# Altium schematic sheet DE-160516.SchDoc(DE-160516)
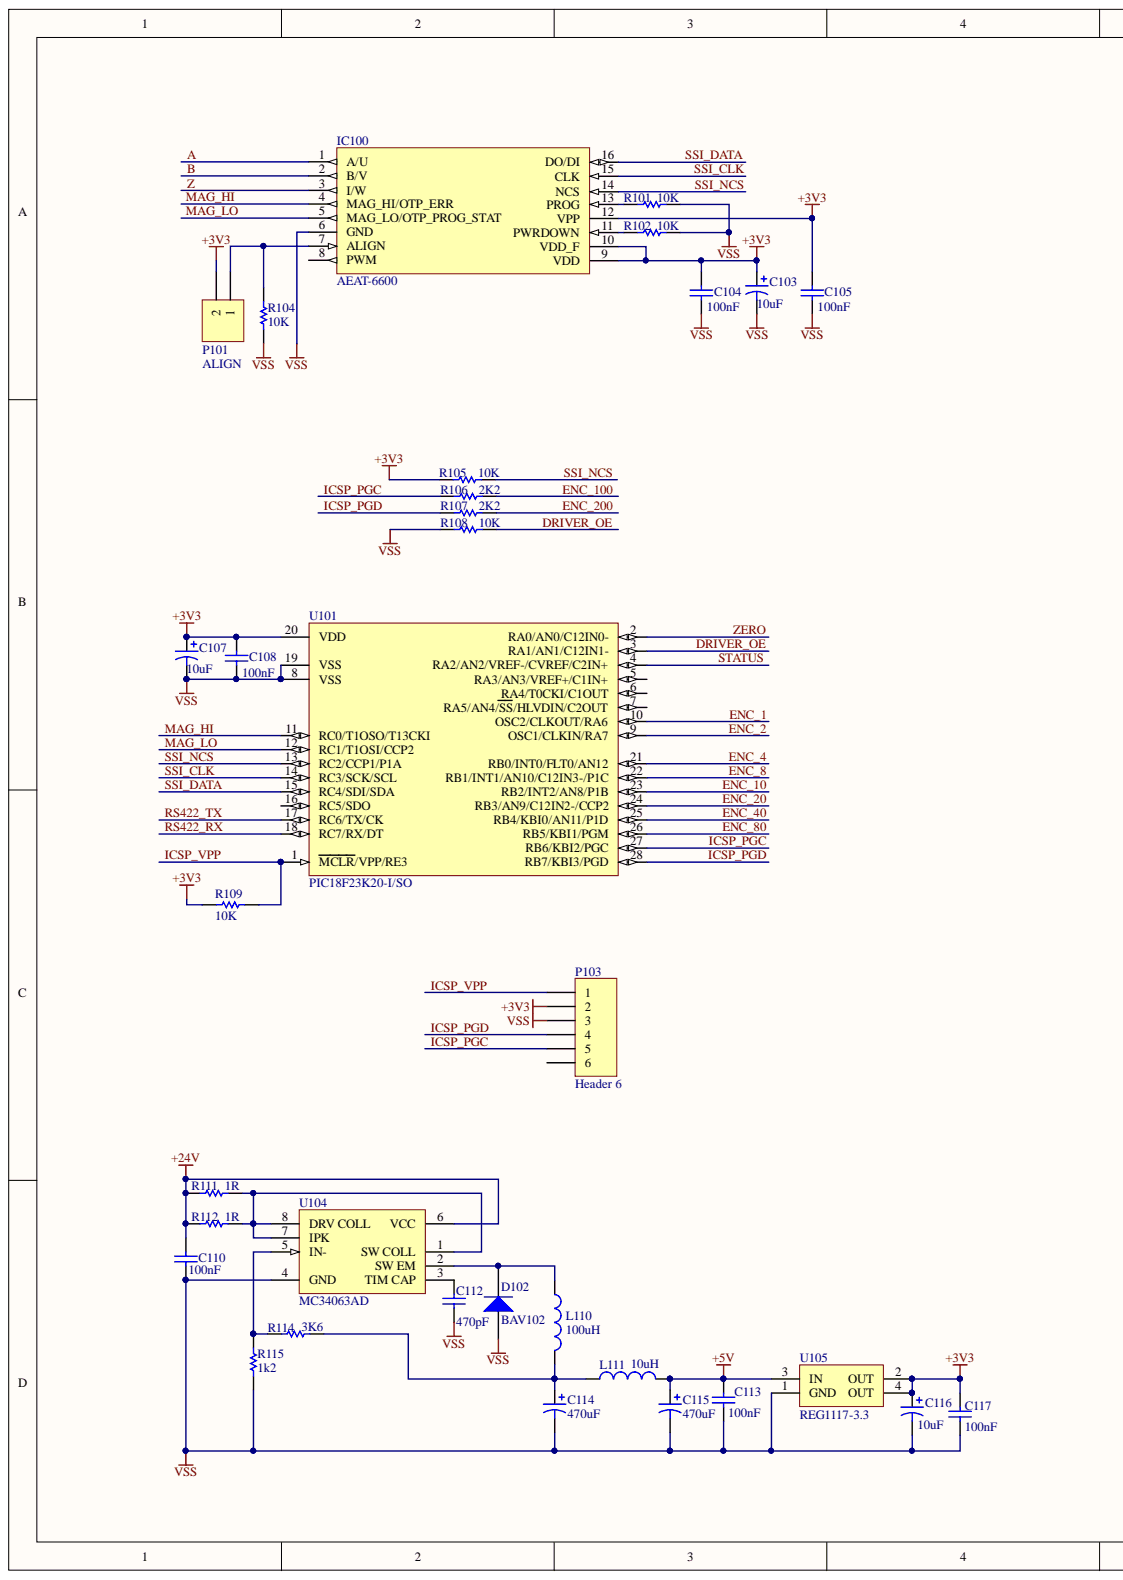
[diagram p0: DE-160516.SchDoc, left]
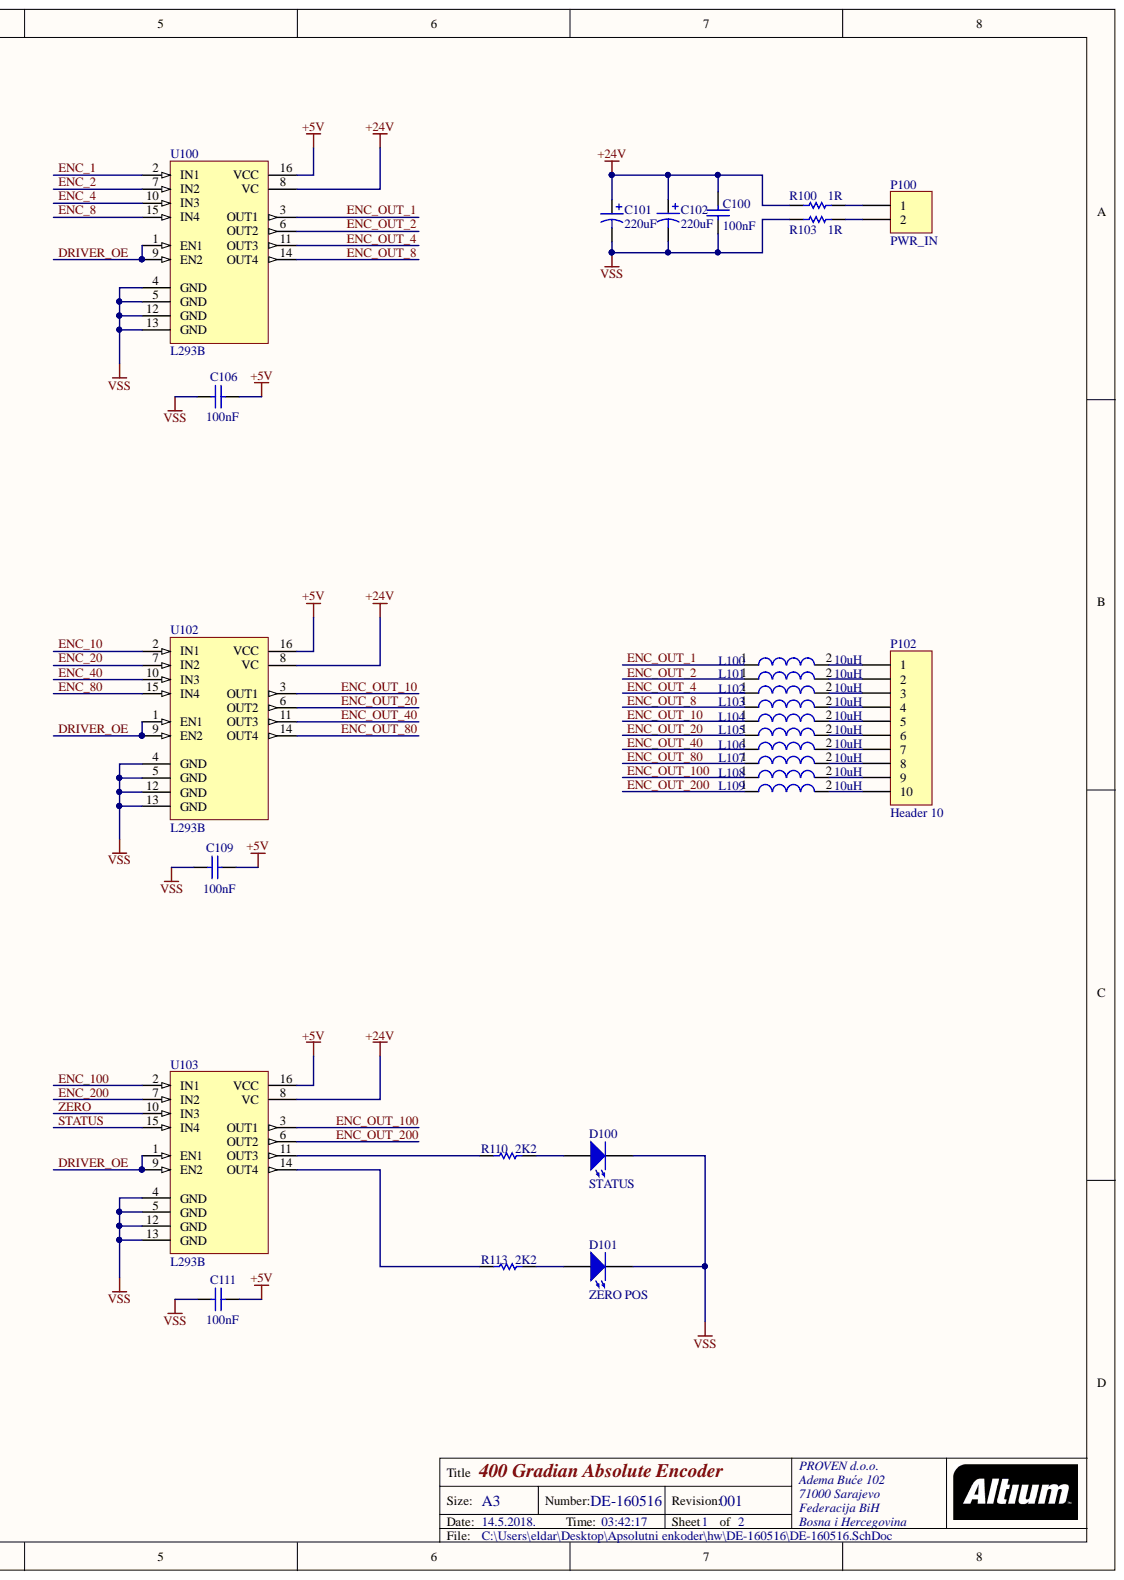
[diagram p1: DE-160516.SchDoc, right]

## Parts
C100  value 100nF  [0805]
C101  220uF  [E]
C102  220uF  [E]
C103  10uF  [C]
C104  value 100nF  [0805]
C105  value 100nF  [0805]
C106  value 100nF  [0805]
C107  10uF  [C]
C108  value 100nF  [0805]
C109  value 100nF  [0805]
C110  value 100nF  [1206]
C111  value 100nF  [0805]
C112  value 470pF  [0805]
C113  value 100nF  [0805]
C114  470uF  [CAPPR2.5-6.3X11]
C115  470uF  [CAPPR2.5-6.3X11]
C116  10uF  [49BC-C]
C117  value 100nF  [0805]
D100  STATUS  [LED 3mm]
D101  ZERO POS  [LED 3mm]
D102  BAV102  [SOD80C]
IC100  AEAT-6600  [TSSOP16]
L100  10uH  [SMD-0603]
L101  10uH  [SMD-0603]
L102  10uH  [SMD-0603]
L103  10uH  [SMD-0603]
L104  10uH  [SMD-0603]
L105  10uH  [SMD-0603]
L106  10uH  [SMD-0603]
L107  10uH  [SMD-0603]
L108  10uH  [SMD-0603]
L109  10uH  [SMD-0603]
L110  value 100uH  [RB5-10.5]
L111  value 10uH  [RB5-10.5]
P100  PWR_IN  [HDR1X2]
P101  ALIGN  [HDR1X2]
P102  Header 10  [HDR1X10]
P103  Header 6  [HDR1X6]
R100  1R  [RD6.35x2.3]
R101  10K  [ERA-0603]
R102  10K  [ERA-0603]
R103  1R  [RD6.35x2.3]
R104  10K  [ERA-0603]
R105  10K  [ERA-0603]
R106  2K2  [ERA-0603]
R107  2K2  [ERA-0603]
R108  10K  [ERA-0603]
R109  10K  [ERA-0603]
R110  2K2  [ERA-0603]
R111  1R  [ERA-0805]
R112  1R  [ERA-0805]
R113  2K2  [ERA-0603]
R114  3K6  [ERA-0805]
R115  1k2  [ERA-0805]
U100  L293B  [DIP16]
U101  PIC18F23K20-I/SO  [SOIC-SO28_N]
U102  L293B  [DIP16]
U103  L293B  [DIP16]
U104  MC34063AD  [751-05_N]
U105  REG1117-3.3  [ZZ311]

## Nets
+3V3: C103.1 C104.2 C105.2 C107.1 C108.2 C116.1 C117.2 IC100.9(VDD) IC100.10(VDD_F) IC100.12(VPP) P101.2 P103.2 R105.2 R109.2 U101.20(VDD) U105.2(OUT) U105.4(OUT)
+5V: C106.1 C109.1 C111.1 C113.2 C115.1 L111.2 U100.16(VCC) U102.16(VCC) U103.16(VCC) U105.3(IN)
+24V: C100.2 C101.1 C102.1 C110.2 R100.1 R111.1 R112.1 U100.8(VC) U102.8(VC) U103.8(VC) U104.6(VCC)
VSS: C100.1 C101.2 C102.2 C103.2 C104.1 C105.1 C106.2 C107.2 C108.1 C109.2 C110.1 C111.2 C112.1 C113.1 C114.2 C115.2 C116.2 C117.1 D100.1 D101.1 D102.1 IC100.6(GND) P103.3 R101.1 R102.1 R103.1 R104.1 R108.2 R115.1 U100.4(GND) U100.5(GND) U100.12(GND) U100.13(GND) U101.8(VSS) U101.19(VSS) U102.4(GND) U102.5(GND) U102.12(GND) U102.13(GND) U103.4(GND) U103.5(GND) U103.12(GND) U103.13(GND) U104.4(GND) U105.1(GND)
A: IC100.1(A/U)
B: IC100.2(B/V)
DRIVER_OE: R108.1 U100.1(EN1) U100.9(EN2) U101.3(RA1/AN1/C12IN1-) U102.1(EN1) U102.9(EN2) U103.1(EN1) U103.9(EN2)
ENC_1: U100.2(IN1) U101.10(OSC2/CLKOUT/RA6)
ENC_2: U100.7(IN2) U101.9(OSC1/CLKIN/RA7)
ENC_4: U100.10(IN3) U101.21(RB0/INT0/FLT0/AN12)
ENC_8: U100.15(IN4) U101.22(RB1/INT1/AN10/C12IN3-/P1C)
ENC_10: U101.23(RB2/INT2/AN8/P1B) U102.2(IN1)
ENC_20: U101.24(RB3/AN9/C12IN2-/CCP2) U102.7(IN2)
ENC_40: U101.25(RB4/KBI0/AN11/P1D) U102.10(IN3)
ENC_80: U101.26(RB5/KBI1/PGM) U102.15(IN4)
ENC_100: R106.1 U103.2(IN1)
ENC_200: R107.1 U103.7(IN2)
ENC_OUT_1: L100.1 U100.3(OUT1)
ENC_OUT_2: L101.1 U100.6(OUT2)
ENC_OUT_4: L102.1 U100.11(OUT3)
ENC_OUT_8: L103.1 U100.14(OUT4)
ENC_OUT_10: L104.1 U102.3(OUT1)
ENC_OUT_20: L105.1 U102.6(OUT2)
ENC_OUT_40: L106.1 U102.11(OUT3)
ENC_OUT_80: L107.1 U102.14(OUT4)
ENC_OUT_100: L108.1 U103.3(OUT1)
ENC_OUT_200: L109.1 U103.6(OUT2)
ICSP_PGC: P103.5 R106.2 U101.27(RB6/KBI2/PGC)
ICSP_PGD: P103.4 R107.2 U101.28(RB7/KBI3/PGD)
ICSP_VPP: P103.1 R109.1 U101.1(MCLR/VPP/RE3)
MAG_HI: IC100.4(MAG_HI/OTP_ERR) U101.11(RC0/T1OSO/T13CKI)
MAG_LO: IC100.5(MAG_LO/OTP_PROG_STAT) U101.12(RC1/T1OSI/CCP2)
RS422_RX: U101.18(RC7/RX/DT)
RS422_TX: U101.17(RC6/TX/CK)
SSI_CLK: IC100.15(CLK) U101.14(RC3/SCK/SCL)
SSI_DATA: IC100.16(DO/DI) U101.15(RC4/SDI/SDA)
SSI_NCS: IC100.14(NCS) R105.1 U101.13(RC2/CCP1/P1A)
STATUS: U101.4(RA2/AN2/VREF-/CVREF/C2IN+) U103.15(IN4)
Z: IC100.3(I/W)
ZERO: U101.2(RA0/AN0/C12IN0-) U103.10(IN3)
NetC112_2: C112.2 U104.3(TIM_CAP)
NetC114_1: C114.1 L110.2 L111.1 R114.2
NetD100_2: D100.2 R110.2
NetD101_2: D101.2 R113.2
NetD102_2: D102.2 L110.1 U104.2(SW_EM)
NetIC100_7: IC100.7(ALIGN) P101.1 R104.2
NetIC100_11: IC100.11(PWRDOWN) R102.2
NetIC100_13: IC100.13(PROG) R101.2
NetL100_2: L100.2 P102.1
NetL101_2: L101.2 P102.2
NetL102_2: L102.2 P102.3
NetL103_2: L103.2 P102.4
NetL104_2: L104.2 P102.5
NetL105_2: L105.2 P102.6
NetL106_2: L106.2 P102.7
NetL107_2: L107.2 P102.8
NetL108_2: L108.2 P102.9
NetL109_2: L109.2 P102.10
NetP100_1: P100.1 R100.2
NetP100_2: P100.2 R103.2
NetR110_1: R110.1 U103.11(OUT3)
NetR111_2: R111.2 R112.2 U104.1(SW_COLL) U104.7(IPK) U104.8(DRV_COLL)
NetR113_1: R113.1 U103.14(OUT4)
NetR114_1: R114.1 R115.2 U104.5(IN-)

Not connected: IC100 8(PWM); P103 6; U101 5(RA3/AN3/VREF+/C1IN+) 6(RA4/T0CKI/C1OUT) 7(RA5/AN4/SS/HLVDIN/C2OUT) 16(RC5/SDO)

## Library data of the parts
C100 C104-C106 C108 C109 C111-C113 C117 = C0805: Ceramic Chip Capacitor - Standard; Note: Footprint corresponds to dimensions for bulk cassette packaging. This case size has tightened tolerances.; Simulation: CAP; Signal Integrity: C0805; Published: 17-Jul-2002; PackageReference: 0805; PackageDocument: Mar-2001; Library Name: KEMET Chip Capacitor.IntLib; LatestRevisionNote: Silkscreen size reduced.; LatestRevisionDate: 19-Mar-2004; DatasheetDocument: Mar-2001; ComponentLink2URL: http://www.kemet.com/kemet/web/homepage/kechome.nsf/vapubfilesname/F3102TA.pdf/$file/F3102TA.pdf; ComponentLink2Description: Datasheet; ComponentLink1URL: http://www.kemet.com/; ComponentLink1Description: Manufacturer Link; Component Kind: Standard; Code_JEITA: 0805; Code_IPC: 2012[0805]; Publisher: Altium Limited
C101 C102 = 94SS107X0020EBP: Solid Aluminum Capacitor with Organic Semiconductor Electrolyte: 100uF, 20V, Bulk Pack; PCB_Layout: Complies with Manufacturer's Recommendation.; Note: Part Numbers shown are for ± 20% capacitance tolerance (X0).; DrillSize: Nominal, Rounded to the nearest 0.1mm; Value: 100uF; Simulation: CAP; Signal Integrity: 94SS107X0020EBP; Published: 19-Mar-2004; PackageReference: E; Library Name: Vishay Electrolytic Radial-Lead Capacitor.IntLib; DatasheetDocument: 23-May-2001; ComponentLink2URL: http://www.vishay.com/docs/90008/94ss.pdf; ComponentLink2Description: Datasheet; ComponentLink1URL: http://www.vishay.com/; ComponentLink1Description: Manufacturer Link; Component Kind: Standard; Publisher: Altium Limited
C103 C107 = 94SC106X0016CBP: Solid Aluminum Capacitor with Organic Semiconductor Electrolyte: 10uF, 16V, Bulk Pack; PCB_Layout: Complies with Manufacturer's Recommendation.; Note: Part Numbers shown are for ± 20% capacitance tolerance (X0).; DrillSize: Nominal, Rounded to the nearest 0.1mm; Value: 10uF; Simulation: CAP; Signal Integrity: 94SC106X0016CBP; Published: 19-Mar-2004; PackageReference: C; Library Name: Vishay Electrolytic Radial-Lead Capacitor.IntLib; DatasheetDocument: 23-May-2001; ComponentLink2URL: http://www.vishay.com/docs/90004/94sc.pdf; ComponentLink2Description: Datasheet; ComponentLink1URL: http://www.vishay.com/; ComponentLink1Description: Manufacturer Link; Component Kind: Standard; Publisher: Altium Limited
C110 = C1206: Ceramic Chip Capacitor - Standard; Simulation: CAP; Signal Integrity: C1206; Published: 17-Jul-2002; PackageReference: 1206; PackageDocument: Mar-2001; Library Name: KEMET Chip Capacitor.IntLib; LatestRevisionNote: Silkscreen size reduced.; LatestRevisionDate: 19-Mar-2004; DatasheetDocument: Mar-2001; ComponentLink2URL: http://www.kemet.com/kemet/web/homepage/kechome.nsf/vapubfilesname/F3102TA.pdf/$file/F3102TA.pdf; ComponentLink2Description: Datasheet; ComponentLink1URL: http://www.kemet.com/; ComponentLink1Description: Manufacturer Link; Component Kind: Standard; Code_JEITA: 1206; Code_IPC: 3216 [1206]; Publisher: Altium Limited
C114 C115 = EKA00BA347C00: Aluminum Electrolytic Capacitor, Radial Style with Long Leads: 470uF, 10V; Note: Refer to Manufacturer's Data Sheets for other lead formations; DrillSize: Nominal, Rounded To The Nearest 0.1mm; Value: 470uF; Simulation: CAP; Signal Integrity: EKA00BA347C00; Published: 19-Mar-2004; PackageReference: CAPPR2.5-6.3X11; DatasheetDocument: 20-Feb-2001; ComponentLink2URL: http://www.vishay.com/docs/25014/eka.pdf; ComponentLink2Description: Datasheet; ComponentLink1URL: http://www.vishay.com/; ComponentLink1Description: Manufacturer Link; Component Kind: Standard; Publisher: Altium Limited; Library Name: Vishay Roederstein Electrolytic Radial-Lead Capacitor.IntLib
C116 = 49BC685B010M: Conformal Coated Solid Tantalum Chip Capacitor: 6.8uF, 20% Tolerance, 10 WVDC @ -55°C to +85°C, Surge = 13V; Note: See Data Sheet for full range of components; Value: 6.8uF; Simulation: CAP; Signal Integrity: 49BC685B010M; Published: 19-Mar-2004; PackageReference: 49BC-C; DatasheetDocument: 05-Jul-2002; ComponentLink1URL: http://www.vishay.com/; ComponentLink1Description: Manufacturer Link; Component Kind: Standard; Publisher: Altium Limited; Library Name: Vishay Sprague Tantalum Chip Capacitor.IntLib
D100 D101 = LED 3mm yellow: LED dioda 3 mm; Library Name: Schlib1.SchLib; Component Kind: Standard
D102 = BAV102: General-purpose Diode; Simulation: BAV103; Signal Integrity: BAV102; Published: 17-Sep-2003; PackageVersion: Jan-2002; PackageReference: SOD80C; PackageDescription: Metal Electrode Face (MELF) Diode; Body 3.5 x 1.6 mm (LxDia); Library Name: Philips Discrete Diode - Switching.IntLib; LatestRevisionNote: Silkscreen size reduced.; LatestRevisionDate: 19-Mar-2004; DatasheetVersion: 17-Sep-1996; ComponentLink2URL: http://www.semiconductors.philips.com/acrobat/datasheets/BAV100_2.pdf; ComponentLink2Description: Datasheet; ComponentLink1URL: http://www.semiconductors.philips.com/; ComponentLink1Description: Manufacturer Link; Component Kind: Standard; Publisher: Altium Limited
IC100 = AEAT-6600: Absolute encoder IC; Library Name: ABS_ENC.SCHLIB; Component Kind: Standard
L100-L109 = 7447962: SMD-Multilayer Inductor WE-MI, L = 0.10µH; PackageVersion: 2009; PackageReference: SMD-0603; PackageDescription: Chip Inductor, 2-Leads, Body 1.6 x 0.8 mm; Library Name: Wuerth Elektronik Filter Inductor.IntLib; Inductance: 0.10 µH; DatasheetVersion: ver 5, Oct-2004; ComponentLink2URL: http://www.we-online.de/katalog/media/pdf/7447962.pdf; ComponentLink2Description: Datasheet; ComponentLink1URL: http://www.we-online.com; ComponentLink1Description: Manufacturer URL; Component Kind: Standard
L110 L111 = PCMC063T-R82MN: SMT Power Choke Coil; Size 7.3×6.6×3.0mm, ±20% Tolerance, 0.82uH, 13A; PCB_Layout: Complies with manufacturer's recommendation.; Simulation: INDUCTOR; Signal Integrity: Inductor; Published: 26-Sep-2008; PackageVersion: Oct-2007; PackageReference: PCMC063T; PackageDescription: SM Power Choke Coil; 2 Leads; Body 7.3 x 6.6 x 3.0 mm (LxWxH); Library Name: SSM Inductor.IntLib; DatasheetVersion: Oct-2007; ComponentLink2URL: http://www.susumu.co.jp/english/pdf/products-j07-01.pdf; ComponentLink2Description: Datasheet; ComponentLink1URL: http://www.susumu.co.jp/; ComponentLink1Description: Manufacturer Link; Component Kind: Standard; Publisher: Altium Limited
P100 P101 = Header 2: Header, 2-Pin; Signal Integrity: Connector; Library Name: Miscellaneous Connectors.IntLib; LatestRevisionNote: Re-released for DXP Platform.; LatestRevisionDate: 17-Jul-2002; Component Kind: Standard; Publisher: Altium Limited
P102 = Header 10: Header, 10-Pin; Signal Integrity: Connector; Library Name: Miscellaneous Connectors.IntLib; LatestRevisionNote: Re-released for DXP Platform.; LatestRevisionDate: 17-Jul-2002; Component Kind: Standard; Publisher: Altium Limited
P103 = Header 6: Header, 6-Pin; Signal Integrity: Connector; Library Name: Miscellaneous Connectors.IntLib; LatestRevisionNote: Re-released for DXP Platform.; LatestRevisionDate: 17-Jul-2002; Component Kind: Standard; Publisher: Altium Limited
R100 R103 = ERD2FC: Flame-Retardant Fuse Type Carbon Film Resistor, 4.7 Ohm to 3.3k Ohm Range, 2% and 5% Tolerance, 0.25 W; Simulation: RESISTOR; Signal Integrity: Res; Published: 17-Dec-2003; PackageReference: RD6.35x2.3; Library Name: Panasonic Resistor.IntLib; ComponentLink2URL: http://www.panasonic.com/industrial/components/pdf/AOB0000CE5.pdf; ComponentLink2Description: Datasheet; ComponentLink1URL: http://www.panasonic.com/industrial/components/resistive_products.htm; ComponentLink1Description: Manufacturer Link; Component Kind: Standard; Publisher: Altium Limited
R101 R102 R104-R110 R113 = ERAV: Metal Film Thermosensitive Chip Resistor, 0.56 Ohm to 10k Ohm Range, 5% Tolerance, 0603 Size, 0.063 W; Note: If flow soldering, adjust pad width of PCB Footprint to 0.7 - 0.8 times the width of the resistor.; Simulation: RESISTOR; Signal Integrity: Res; Published: 17-Dec-2003; PackageReference: ERA-0603; PackageDocument: Mar-2003; Library Name: Panasonic Resistor.IntLib; LatestRevisionNote: Silkscreen size reduced.; LatestRevisionDate: 19-Mar-2004; ComponentLink2URL: http://www.panasonic.com/industrial/components/pdf/AOF0000CE1.pdf; ComponentLink2Description: Datasheet; ComponentLink1URL: http://www.panasonic.com/industrial/components/resistive_products.htm; ComponentLink1Description: Manufacturer Link; Component Kind: Standard; Code_IPC: 1608 [0603]; Publisher: Altium Limited
R111 R112 R114 R115 = ERAS: Metal Film Thermosensitive Chip Resistor, 0.56 Ohm to 10k Ohm Range, 5% Tolerance, 0805 Size, 0.1 W; Note: If flow soldering, adjust pad width of PCB Footprint to 0.7 - 0.8 times the width of the resistor.; Simulation: RESISTOR; Signal Integrity: Res; Published: 17-Dec-2003; PackageReference: ERA-0805; PackageDocument: Mar-2003; Library Name: Panasonic Resistor.IntLib; LatestRevisionNote: Silkscreen size reduced.; LatestRevisionDate: 19-Mar-2004; ComponentLink2URL: http://www.panasonic.com/industrial/components/pdf/AOF0000CE1.pdf; ComponentLink2Description: Datasheet; ComponentLink1URL: http://www.panasonic.com/industrial/components/resistive_products.htm; ComponentLink1Description: Manufacturer Link; Component Kind: Standard; Code_IPC: 2012 [0805]; Publisher: Altium Limited
U100 U102 U103 = L293B: Push-Pull Four Channel Drivers; Published: 8-Jun-2000; PCB3D: DIP16; PackageVersion: Apr-1996; PackageReference: DIP16; PackageDescription: DIP; 16 Leads; Row Spacing 7.62 mm; Pitch 2.54 mm; Library Name: ST Interface DC & Stepper Motor Controller.IntLib; LatestRevisionNote: Stylized 3D Model Added.; LatestRevisionDate: 06-Jun-2005; DatasheetVersion: Apr-1993; ComponentLink2URL: http://www.st.com/stonline/products/literature/ds/1328/l293b.pdf; ComponentLink2Description: Datasheet; ComponentLink1URL: http://www.st.com/; ComponentLink1Description: Manufacturer Link; Component Kind: Standard; Publisher: Altium Limited
U101 = PIC18F23K20-I/SO: Flash Microcontroller with nanoWatt XLP Technology, 28-pin SOIC, Industrial Temperature; Supplier Part Number 2: 1700989; Supplier Part Number 1: PIC18F23K20-I/SO-ND; Supplier 2: Farnell; Supplier 1: Digi-Key; Published: 2-Dec-2009; PackageVersion: revBB, Aug-2009; PackageReference: SOIC-SO28; PackageDescription: 28-Lead Plastic Small Outline (SO) - Wide 7.50mm Body [SOIC]; Library Name: Microchip Microcontroller 8-Bit PIC18.IntLib; DatasheetVersion: revF, Sep-2009; ComponentLink3URL: http://www.microchip.com/; ComponentLink3Description: Microchip.com Home; ComponentLink2URL: http://www.microchip.com/stellent/groups/techpub_sg/documents/packagingspec/en012702.pdf; ComponentLink2Description: Packaging Specification; ComponentLink1URL: http://ww1.microchip.com/downloads/en/DeviceDoc/41303F.pdf; ComponentLink1Description: Datasheet; Component Kind: Standard; Code_JEDEC: MS-013; Publisher: Altium Limited
U104 = MC34063AD: DC-to-DC Converter Control Circuit; Published: 15-Apr-2002; PackageVersion: 1998; PackageReference: 751-05; PackageDescription: 8-Pin Small Outline Integrated Circuit 1.27 mm Pitch; Library Name: ON Semi Power Mgt DC-DC Converter.IntLib; LatestRevisionNote: IPC-7351 Footprint Added.; LatestRevisionDate: 13-Apr-2006; DatasheetVersion: 1996; ComponentLink2URL: http://www.onsemi.com/pub/Collateral/MC34063A-D.PDF; ComponentLink2Description: Datasheet; ComponentLink1URL: http://www.onsemi.com/site/products/taxonomy/0,4438,503,00.html; ComponentLink1Description: Manufacturer Link; Component Kind: Standard; Code_JEDEC: MS-012-AA; Code_IPC: SOIC127P600-8; Publisher: Altium Limited
U105 = REG1117-3.3: 800mA and 1A Low Dropout Positive Regulator 2.85V, 3.3V, 5V, and Adjustable; Published: 8-Jun-2000; PackageVersion: revC; PackageReference: ZZ311; PackageDescription: SOT-223/SC-73; 4 Leads; Body 6.7 x 7.3 mm, inc. leads (LxW max); Library Name: BB Power Mgt Voltage Regulator.IntLib; LatestRevisionNote: Re-released for DXP Platform.; LatestRevisionDate: 17-Jul-2002; DatasheetVersion: Sep-1997; ComponentLink2URL: http://www-s.ti.com/sc/ds/REG1117.pdf; ComponentLink2Description: Datasheet; ComponentLink1URL: http://www.ti.com/; ComponentLink1Description: Manufacturer Link; Component Kind: Standard; Publisher: Altium Limited
